# Altium schematic sheet watchdog.SchDoc
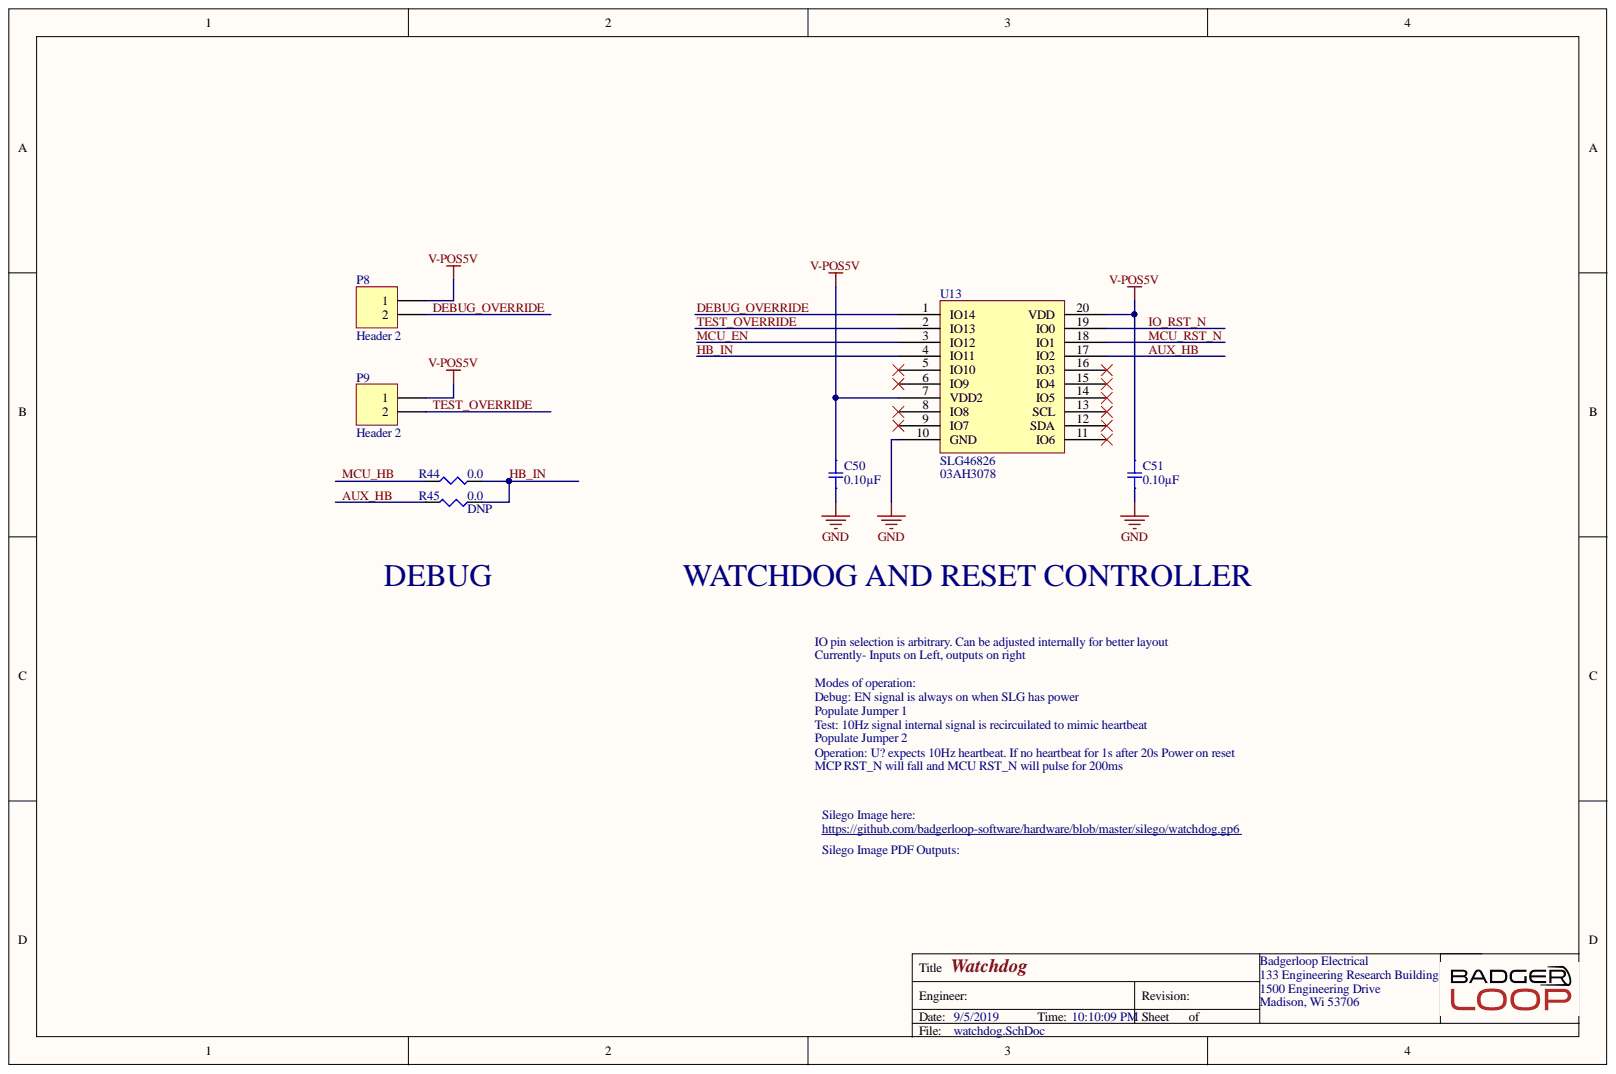
[diagram p0: watchdog.SchDoc]

## Parts
C50  0.10µF  [SMD-0805C]
C51  0.10µF  [SMD-0805C]
P8  Header 2  [Pin Header 1x2 TH Pitch 2.54mm]
P9  Header 2  [Pin Header 1x2 TH Pitch 2.54mm]
R44  0.0  [SMD-0805-RES]
R45  0.0  [SMD-0805-RES]
U13  SLG46826  Supplier Part Number 1: 03AH3078  [SOP65P640X175-20N]

## Nets
GND: C50.2 C51.2 U13.10(GND)
V-POS5V: C50.1 C51.1 P8.1 P9.1 U13.7(VDD2) U13.20(VDD)
AUX_HB: R45.2 U13.17(IO2)
DEBUG_OVERRIDE: P8.2 U13.1(IO14)
HB_IN: R44.2 R45.1 U13.4(IO11)
IO_RST_N: U13.19(IO0)
MCU_EN: U13.3(IO12)
MCU_HB: R44.1
MCU_RST_N: U13.18(IO1)
TEST_OVERRIDE: P9.2 U13.2(IO13)

Not connected: U13 5(IO10) 6(IO9) 8(IO8) 9(IO7) 11(IO6) 12(SDA) 13(SCL) 14(IO5) 15(IO4) 16(IO3)

## Library data of the parts
C50 C51 = GRM219R71E104KA01D: CAP CER 0.1UF 25V X7R 0805; Manufacturer: Murata Electronics North America; @ qty: 0; Minimum Quantity: 1; Tolerance: ±10%; Unit Price (USD): 0.12; Applications: General Purpose; Component Kind: Standard; Datasheets: http://www.murata.com/~/media/webrenewal/support/library/catalog/products/capacitor/mlcc/c02e.pdf; Digi-Key Part Number: 490-12754-1-ND; Factory Stock: 0; Features: -; Height - Seated (Max): -; Image: //media.digikey.com/Renders/Murata%20Renders/0805%20(2012%20Metric)%20.85mm.jpg; Lead Spacing: -; Lead Style: -; Library Name: capacitors.SchLib; Mounting Type: Surface Mount, MLCC; Operating Temperature: -55°C ~ 125°C; Package / Case: 0805 (2012 Metric); Packaging: Cut Tape (CT); Part Number: GRM219R71E104KA01D; Part Status: Active; Quantity Available: 8594; Ratings: -; Series: GRM; Size / Dimension: 0.079" L x 0.049" W (2.00mm x 1.25mm); Temperature Coefficient: X7R; Thickness (Max): 0.037" (0.95mm); Value: 0.10µF; Voltage: 25V
P8 P9 = Header 2: Header, 2-Pin; Component Kind: Standard; LatestRevisionDate: 17-Jul-2002; LatestRevisionNote: Re-released for DXP Platform.; Library Name: connector.SchLib; Signal Integrity: Connector; Publisher: Altium Limited
R44 R45 = ERJ-6GEY0R00V: RES SMD 0.0 OHM JUMPER 1/8W 0805; Manufacturer: Panasonic Electronic Components; @ qty: 1500; Factory Stock: 0; Minimum Quantity: 1; Power (Watts): 0.125W, 1/8W; Unit Price (USD): 0.00544; Component Kind: Standard; Composition: Thick Film; Datasheets: http://industrial.panasonic.com/www-cgi/jvcr13pz.cgi?E+PZ+3+AOA0001+ERJ6GEY0R00V+7+WW; Digi-Key Part Number: P0.0ACT-ND; Failure Rate: -; Features: Automotive AEC-Q200; Height - Seated (Max): 0.028" (0.70mm); Image: //media.digikey.com/Renders/Panasonic%20Renders/ERJ-0805%20Pkg.jpg; Library Name: resistors.SchLib; Number of Terminations: 2; Operating Temperature: -55°C ~ 155°C; Package / Case: 0805 (2012 Metric); Packaging: Cut Tape (CT); Part Number: ERJ-6GEY0R00V; Part Status: Active; Quantity Available: 1597627; Series: ERJ; Size / Dimension: 0.079" L x 0.049" W (2.00mm x 1.25mm); Supplier Device Package: 0805; Temperature Coefficient: -; Tolerance: Jumper; Value: 0.0
  R45: DNP: DNP
U13 = SLG46826: GreenPAK Programmable Mixed Signal Matrix with In-System Programmability; Manufacturer: Dialog Semiconductor; Manufacturer Part Number: SLG46826V-SKT; Component Kind: Standard; Library Name: ic.SchLib; Pricing 1: 1=45.65000, 5=44.43000, 10=43.15000, 25=42.51000, 50=41.89000, 100=39.38000 (USD); RoHS: No; Stock 1: 4; Supplier 1: Newark
